# Altium schematic sheet SCH_BMS.SchDoc(SCH_BMS)
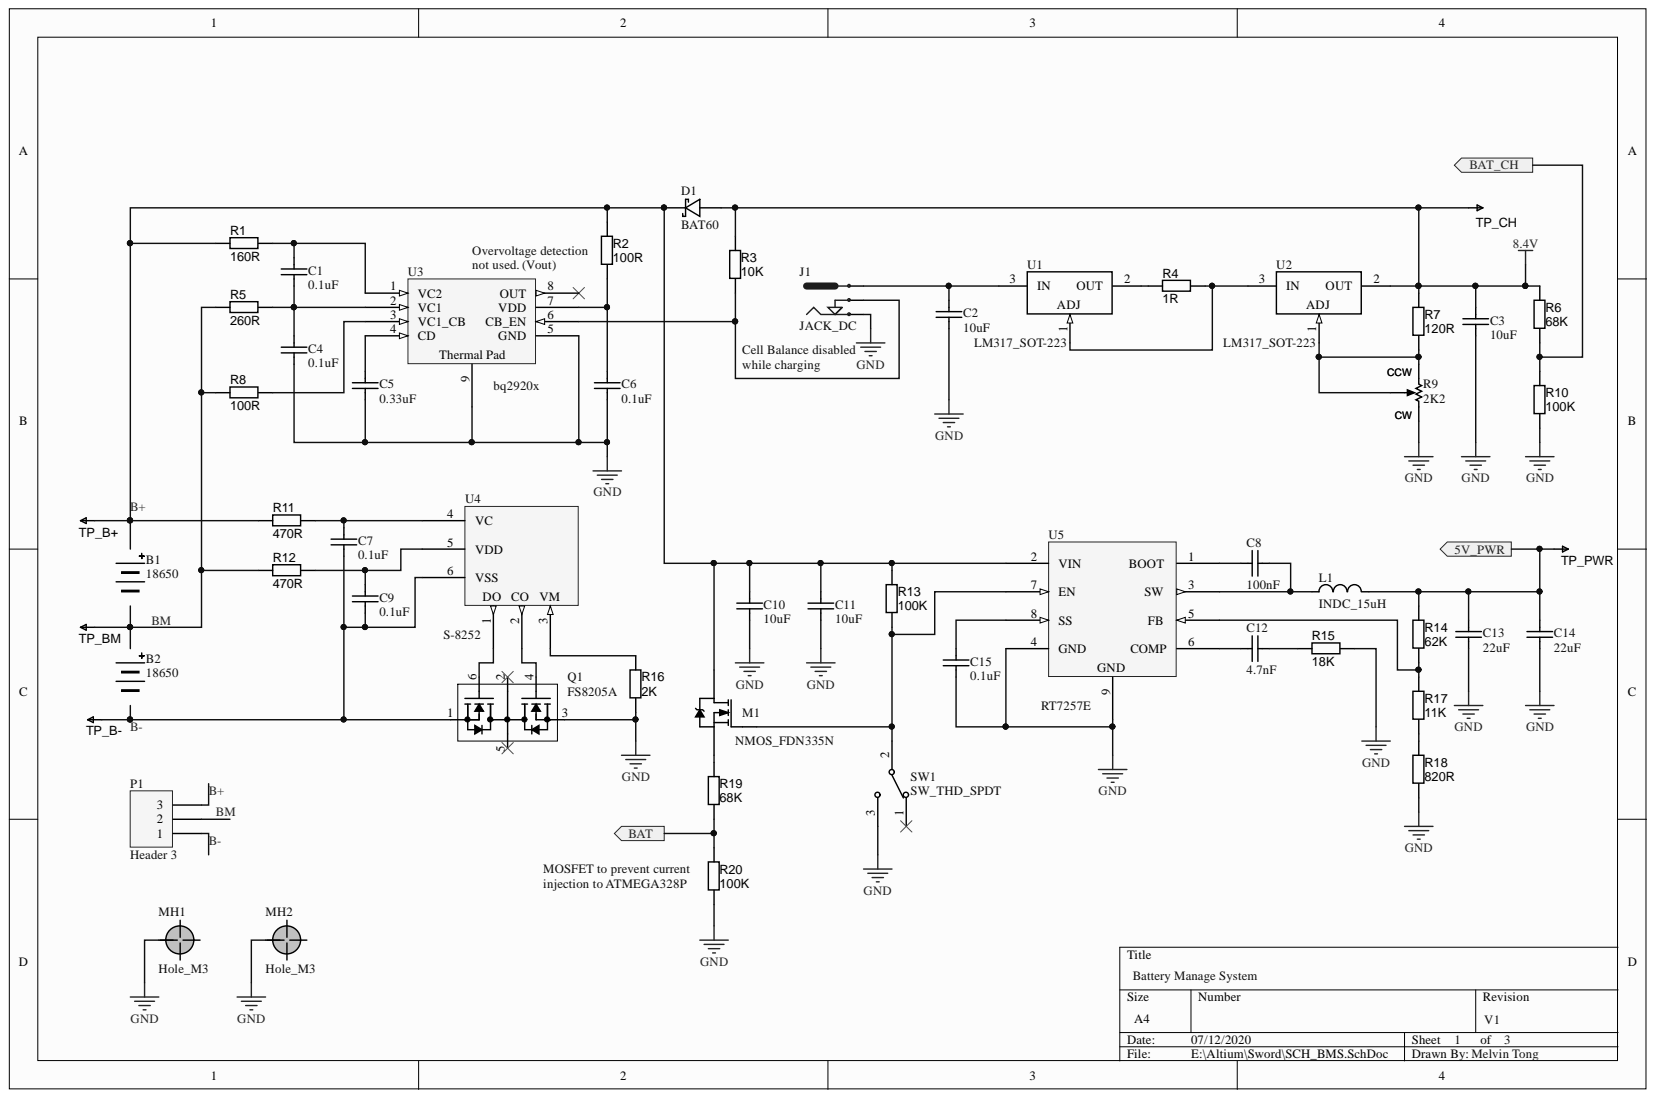
[diagram p0: SCH_BMS.SchDoc]

## Parts
B1  18650
B2  18650
C1  0.1uF  [CAP_0805]
C2  10uF  [CAP_0805]
C3  10uF  [CAP_1206]
C4  0.1uF  [CAP_0805]
C5  0.33uF  [CAP_0805]
C6  0.1uF  [CAP_0805]
C7  0.1uF  [CAP_0805]
C8  100nF  [CAP_0805]
C9  0.1uF  [CAP_0805]
C10  10uF  [CAP_1206]
C11  10uF  [CAP_1206]
C12  4.7nF  [CAP_0805]
C13  22uF  [CAP_1206]
C14  22uF  [CAP_1206]
C15  0.1uF  [CAP_0805]
D1  BAT60  [SOD323]
J1  JACK_DC  [DC-004]
L1  INDC_15uH  [IND_15uH]
M1  NMOS_FDN335N  [SOT23_213]
MH1  Hole_M3  [Hole_M3]
MH2  Hole_M3  [Hole_M3]
P1  Header 3  [HDR1X3]
Q1  FS8205A  [FS8205A]
R1  160R  [RESC3216X70N]
R2  100R  [RESC3216X70N]
R3  10K  [RESC3216X70N]
R4  value 1R  [RESC6332X70N]  (hidden comment: RES_1R_2512)
R5  260R  [RESC3216X70N]
R6  68K  [RESC3216X70N]
R7  120R  [RESC3216X70N]
R8  100R  [RESC3216X70N]
R9  2K2  [RES_VAR]
R10  100K  [RESC3216X70N]
R11  470R  [RESC3216X70N]
R12  470R  [RESC3216X70N]
R13  100K  [RESC3216X70N]
R14  62K  [RESC3216X70N]
R15  18K  [RESC3216X70N]
R16  2K  [RESC3216X70N]
R17  11K  [RESC3216X70N]
R18  820R  [RESC3216X70N]
R19  68K  [RESC3216X70N]
R20  100K  [RESC3216X70N]
SW1  SW_THD_SPDT  [3P_header]
TP_B+  TESTPOINT  [TP-R20]  (value not drawn)  (no BOM)
TP_B-  TESTPOINT  [TP-R20]  (value not drawn)  (no BOM)
TP_BM  TESTPOINT  [TP-R20]  (value not drawn)  (no BOM)
TP_CH  TESTPOINT  [TP-R20]  (value not drawn)  (no BOM)
TP_PWR  TESTPOINT  [TP-R20]  (value not drawn)  (no BOM)
U1  LM317_SOT-223  [SOT223]
U2  LM317_SOT-223  [SOT223]
U3  bq2920x  [bq2920x]
U4  S-8252  [S-8252]
U5  RT7257E  [RT7257E]

## Ports
5V_PWR, BAT, BAT_CH

## Nets
8.4V: C3.1 D1.A R3.1 R6.1 R7.1 TP_CH.1 U2.2
GND: C2.2 C3.2 C4.2 C5.2 C6.2 C10.2 C11.2 C13.2 C14.2 C15.2 J1.1 MH1.MH MH2.MH Q1.3 R9.3 R10.2 R15.2 R16.2 R18.2 R20.2 SW1.3 U3.5(GND) U3.9 U5.4(GND) U5.9
5V_PWR: C13.1 C14.1 L1.2 R14.1 TP_PWR.1 ; port 5V_PWR
B+: C10.1 C11.1 D1.K M1.3 P1.3 R1.1 R2.2 R11.1 R13.1 TP_B+.1 U5.2(VIN)
B-: C7.2 C9.2 P1.1 Q1.1 TP_B-.1 U4.6(VSS)
BAT: R19.2 R20.1 ; port BAT
BAT_CH: R6.2 R10.1 ; port BAT_CH
BM: P1.2 R5.1 R8.1 R12.1 TP_BM.1
NetC1_1: C1.1 R1.2 U3.1(VC2)
NetC1_2: C1.2 C4.1 R5.2 U3.2(VC1)
NetC2_1: C2.1 J1.2 U1.3
NetC5_1: C5.1 U3.4(CD)
NetC6_1: C6.1 R2.1 U3.7(VDD)
NetC7_1: C7.1 R11.2 U4.4(VC)
NetC8_1: C8.1 U5.1(BOOT)
NetC8_2: C8.2 L1.1 U5.3(SW)
NetC9_1: C9.1 R12.2 U4.5(VDD)
NetC12_1: C12.1 U5.6(COMP)
NetC12_2: C12.2 R15.1
NetC15_1: C15.1 U5.8(SS)
NetJ1_3: J1.3 R3.2 U3.6(CB_EN)
NetM1_1: M1.1 R13.2 SW1.2 U5.7(EN)
NetM1_2: M1.2 R19.1
NetQ1_4: Q1.4 U4.2(CO)
NetQ1_6: Q1.6 U4.1(DO)
NetR4_1: R4.1 U1.2
NetR4_2: R4.2 U1.1 U2.3
NetR7_2: R7.2 R9.1 R9.2 U2.1
NetR8_2: R8.2 U3.3(VC1_CB)
NetR14_2: R14.2 R17.1 U5.5(FB)
NetR16_1: R16.1 U4.3(VM)
NetR17_2: R17.2 R18.1

Not connected: Q1 2 5; SW1 1; U3 8(OUT)

## Library data of the parts
B1 B2 = Battery_18650: Component Kind: Standard; Library Name: Schlib_Sword.SchLib
C1 C4 C6 C7 C9 C15 = CAP_0.1uF: SMD 0805; Component Kind: Standard; Library Name: Schlib_Sword.SchLib
C2 C3 C10 C11 = CAP_10uF: SMD 0805/1206; Component Kind: Standard; Library Name: Schlib_Sword.SchLib
C5 = CAP_330nF: SMD 0805; Component Kind: Standard; Library Name: Schlib_Sword.SchLib
C8 = CAP_100nF: SMD 0805; Component Kind: Standard; Library Name: Schlib_Sword.SchLib
C12 = CAP_4.7nF: SMD 0805; Component Kind: Standard; Library Name: Schlib_Sword.SchLib
C13 C14 = CAP_22uF: SMD 1206; Component Kind: Standard; Library Name: Schlib_Sword.SchLib
D1 = BAT60: Schottky Diode 3A, Vf~0.24V, Vr=10V; Component Kind: Standard; Library Name: Schlib_Sword.SchLib
L1 = INDC_15uH: 15uH ±20% 4.2A 28.4mΩ SMD,12.5x12.5x6.5mm Power Inductors; Component Kind: Standard; Library Name: Schlib_Sword.SchLib
P1 = Header 3: Header, 3-Pin; Signal Integrity: Connector; Library Name: Miscellaneous Connectors.IntLib; LatestRevisionNote: Re-released for DXP Platform.; LatestRevisionDate: 17-Jul-2002; Component Kind: Standard; Publisher: Altium Limited
Q1 = FS8205A: NMOS, Drain-Connected, Imax 6A; Component Kind: Standard; Library Name: Schlib_Sword.SchLib
R1 = RES_160R: Resistor SMD, 1206; Value: N/A; Component Kind: Standard; Library Name: Schlib_Sword.SchLib
R2 R8 = RES_100R: Resistor SMD, 1206; Value: N/A; Component Kind: Standard; Library Name: Schlib_Sword.SchLib
R3 = RES_10K: Resistor SMD, 1206; Value: N/A; Component Kind: Standard; Library Name: Schlib_Sword.SchLib
R4 = RES_1R_2512: Resistor SMD 1Ω ±5% 2W ±400ppm/℃ 2512; SCDType: spec; Ratings: 1W; Tolerance: ±5%; Package / Case: 2512 (6332 metric); Material: Thick Film; Component Kind: Standard; Library Name: Schlib_Sword.SchLib
R5 = RES_260R: Resistor SMD, 1206; Value: N/A; Component Kind: Standard; Library Name: Schlib_Sword.SchLib
R6 R19 = RES_68K: Resistor SMD, 1206; Value: N/A; Component Kind: Standard; Library Name: Schlib_Sword.SchLib
R7 = RES_120R: Resistor SMD, 1206; Value: N/A; Component Kind: Standard; Library Name: Schlib_Sword.SchLib
R9 = RES_Var_2K2: Resistor SMD Variable; Component Kind: Standard; Library Name: Schlib_Sword.SchLib
R10 R13 R20 = RES_100K: Resistor SMD, 1206; Value: N/A; Component Kind: Standard; Library Name: Schlib_Sword.SchLib
R11 R12 = RES_470R: Resistor SMD, 1206; Value: N/A; Component Kind: Standard; Library Name: Schlib_Sword.SchLib
R14 = RES_62K: Resistor SMD, 1206; Value: N/A; Component Kind: Standard; Library Name: Schlib_Sword.SchLib
R15 = RES_18K: Resistor SMD, 1206; Value: N/A; Component Kind: Standard; Library Name: Schlib_Sword.SchLib
R16 = RES_2K: Resistor SMD, 1206; Value: N/A; Component Kind: Standard; Library Name: Schlib_Sword.SchLib
R17 = RES_11K: Resistor SMD, 1206; Value: N/A; Component Kind: Standard; Library Name: Schlib_Sword.SchLib
R18 = RES_820R: Resistor SMD, 1206; Value: N/A; Component Kind: Standard; Library Name: Schlib_Sword.SchLib
SW1 = SW_THD_SPDT: Switch 2 position; Component Kind: Standard; Library Name: Schlib_Sword.SchLib
TP_B+ TP_B- TP_BM TP_CH TP_PWR = TESTPOINT: SMD test pin; Library Name: Schlib_Sword.SchLib
U1 U2 = LM317_SOT-223: Linear Voltage Regulator; Component Kind: Standard; Library Name: Schlib_Sword.SchLib
U3 = bq2920x: Automatic Cell Balance 2S Li-Ion Battery; Component Kind: Standard; Library Name: Schlib_Sword.SchLib
U4 = S-8252: Battery protection IC for 2S battery pack; Component Kind: Standard; Library Name: Schlib_Sword.SchLib
U5 = RT7257E: DC/DC Buck Converter, 3A, 4.5V-17V Vin; Component Kind: Standard; Library Name: Schlib_Sword.SchLib
J1 = JACK_DC: Component Kind: Standard; Library Name: Schlib_Sword.SchLib
M1 = NMOS_FDN335N: Component Kind: Standard; Library Name: Schlib_Sword.SchLib
MH1 MH2 = Hole_M3: Component Kind: Standard; Library Name: Schlib_Sword.SchLib
